# Altium schematic sheet PIC32CK.SchDoc(PIC32CK)
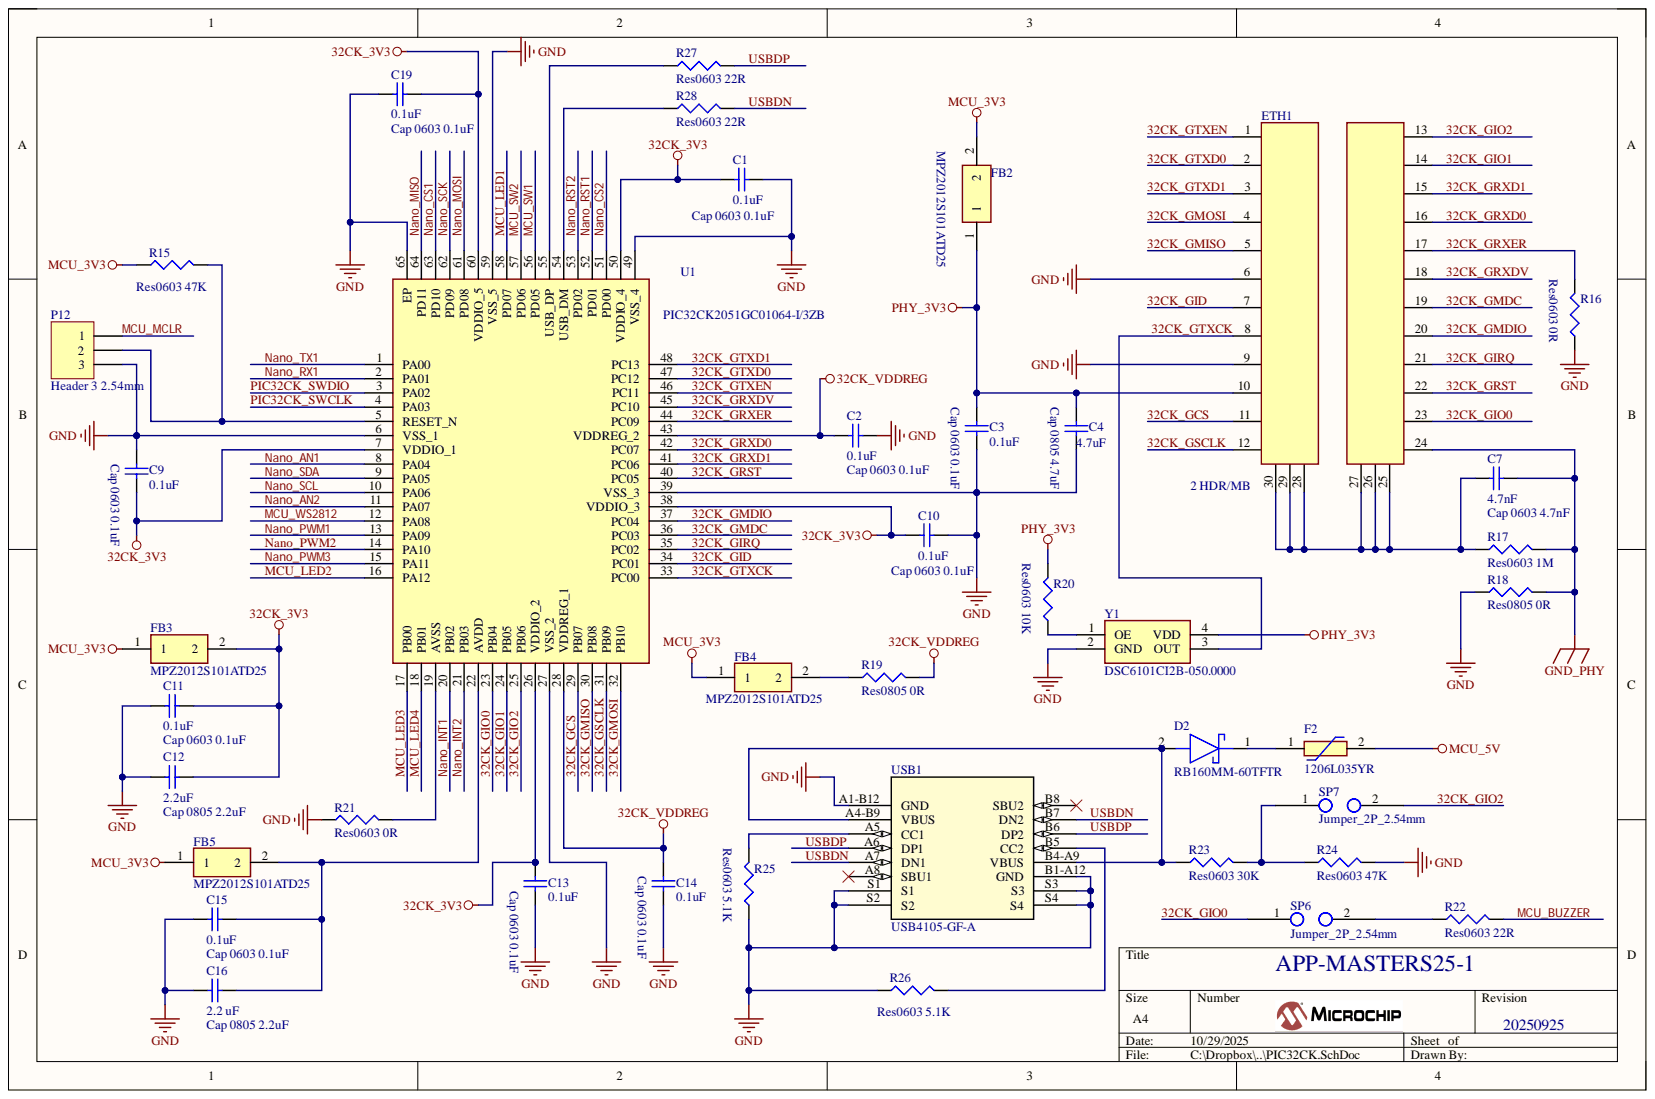
[diagram p0: PIC32CK.SchDoc]

## Parts
C1  Cap 0603 0.1uF  value 0.1uF  [0603C]
C2  Cap 0603 0.1uF  value 0.1uF  [0603C]
C3  Cap 0603 0.1uF  value 0.1uF  [0603C]
C4  Cap 0805 4.7uF  value 4.7uF  [C0805]
C7  Cap 0603 4.7nF  value 4.7nF  [0603C]
C9  Cap 0603 0.1uF  value 0.1uF  [0603C]
C10  Cap 0603 0.1uF  value 0.1uF  [0603C]
C11  Cap 0603 0.1uF  value 0.1uF  [0603C]
C12  Cap 0805 2.2uF  value 2.2uF  [C0805]
C13  Cap 0603 0.1uF  value 0.1uF  [0603C]
C14  Cap 0603 0.1uF  value 0.1uF  [0603C]
C15  Cap 0603 0.1uF  value 0.1uF  [0603C]
C16  Cap 0805 2.2uF  value 2.2 uF  [C0805]
C19  Cap 0603 0.1uF  value 0.1uF  [0603C]
D2  RB160MM-60TFTR  [PMDU]
ETH1  2 HDR/MB  [MOD_LAN8740_PHY_Daughter_Board]
F2  1206L035YR  [1206L05015YR]
FB2  MPZ2012S101ATD25  [BEADC2012X105N]
FB3  MPZ2012S101ATD25  [BEADC2012X105N]
FB4  MPZ2012S101ATD25  [BEADC2012X105N]
FB5  MPZ2012S101ATD25  [BEADC2012X105N]
P12  Header 3 2.54mm  [HDR1X3]
R15  Res0603 47K  value 47K  [0603R]
R16  Res0603 0R  value 0R  [0603R]
R17  Res0603 1M  value 1M  [0603R]
R18  Res0805 0R  value 0R  [RES0805]
R19  Res0805 0R  value 0R  [RES0805]
R20  Res0603 10K  value 10K  [0603R]
R21  Res0603 0R  value 0R  [0603R]
R22  Res0603 22R  value 22R  [0603R]
R23  Res0603 30K  value 30K  [0603R]
R24  Res0603 47K  value 47K  [0603R]
R25  Res0603 5.1K  value 5.1K  [0603R]
R26  Res0603 5.1K  value 5.1K  [0603R]
R27  Res0603 22R  value 22R  [0603R]
R28  Res0603 22R  value 22R  [0603R]
SP6  Jumper_2P_2.54mm  [HDR1X2]
SP7  Jumper_2P_2.54mm  [HDR1X2]
U1  PIC32CK2051GC01064-I/3ZB  [QFP50P1200X1200X120-65N]  (value not drawn)
USB1  USB4105-GF-A  [USB4105_GCT]
Y1  DSC6101CI2B-050.0000  [DSC6101CI1A0260000]

## Nets
32CK_3V3: C1.1 C9.1 C10.1 C11.2 C12.2 C13.1 C19.2 FB3.2 U1.7(VDDIO_1) U1.26(VDDIO_2) U1.38(VDDIO_3) U1.50(VDDIO_4) U1.60(VDDIO_5)
32CK_VDDREG: C2.1 C14.1 R19.2 U1.28(VDDREG_1) U1.43(VDDREG_2)
GND: C1.2 C2.2 C3.1 C4.1 C9.2 C10.2 C11.1 C12.1 C13.2 C14.2 C15.1 C16.1 C19.1 ETH1.6 ETH1.9 P12.3 R16.1 R18.1 R21.1 R24.2 R25.1 R26.1 U1.6(VSS_1) U1.27(VSS_2) U1.39(VSS_3) U1.49(VSS_4) U1.59(VSS_5) U1.65(EP) USB1.A1-B12(GND) USB1.B1-A12(GND) USB1.S1 USB1.S2 USB1.S3 USB1.S4 Y1.2(GND)
GND_PHY: C7.2 ETH1.24 R17.2 R18.2
MCU_3V3: FB2.2 FB3.1 FB4.1 FB5.1 R15.1
MCU_5V: F2.2
PHY_3V3: C3.2 C4.2 ETH1.10 FB2.1 R20.2 Y1.4(VDD)
32CK_GCS: ETH1.11 U1.29(PB07)
32CK_GID: ETH1.7 U1.34(PC01)
32CK_GIO0: ETH1.23 SP6.1 U1.23(PB04)
32CK_GIO1: ETH1.14 U1.24(PB05)
32CK_GIO2: ETH1.13 SP7.2 U1.25(PB06)
32CK_GIRQ: ETH1.21 U1.35(PC02)
32CK_GMDC: ETH1.19 U1.36(PC03)
32CK_GMDIO: ETH1.20 U1.37(PC04)
32CK_GMISO: ETH1.5 U1.30(PB08)
32CK_GMOSI: ETH1.4 U1.32(PB10)
32CK_GRST: ETH1.22 U1.40(PC05)
32CK_GRXD0: ETH1.16 U1.42(PC07)
32CK_GRXD1: ETH1.15 U1.41(PC06)
32CK_GRXDV: ETH1.18 U1.45(PC10)
32CK_GRXER: ETH1.17 R16.2 U1.44(PC09)
32CK_GSCLK: ETH1.12 U1.31(PB09)
32CK_GTXCK: ETH1.8 U1.33(PC00) Y1.3(OUT)
32CK_GTXD0: ETH1.2 U1.47(PC12)
32CK_GTXD1: ETH1.3 U1.48(PC13)
32CK_GTXEN: ETH1.1 U1.46(PC11)
MCU_BUZZER: R22.2
MCU_LED1: U1.58(PD07)
MCU_LED2: U1.16(PA12)
MCU_LED3: U1.17(PB00)
MCU_LED4: U1.18(PB01)
MCU_MCLR: P12.1
MCU_SW1: U1.56(PD05)
MCU_SW2: U1.57(PD06)
MCU_WS2812: U1.12(PA08)
Nano_AN1: U1.8(PA04)
Nano_AN2: U1.11(PA07)
Nano_CS1: U1.63(PD10)
Nano_CS2: U1.51(PD00)
Nano_INT1: U1.20(PB02)
Nano_INT2: U1.21(PB03)
Nano_MISO: U1.64(PD11)
Nano_MOSI: U1.61(PD08)
Nano_PWM1: U1.13(PA09)
Nano_PWM2: U1.14(PA10)
Nano_PWM3: U1.15(PA11)
Nano_RST1: U1.52(PD01)
Nano_RST2: U1.53(PD02)
Nano_RX1: U1.2(PA01)
Nano_SCK: U1.62(PD09)
Nano_SCL: U1.10(PA06)
Nano_SDA: U1.9(PA05)
Nano_TX1: U1.1(PA00)
PIC32CK_SWCLK: U1.4(PA03)
PIC32CK_SWDIO: U1.3(PA02)
USBDN: R28.2 USB1.A7(DN1) USB1.B7(DN2)
USBDP: R27.2 USB1.A6(DP1) USB1.B6(DP2)
NetC7_1: C7.1 ETH1.25 ETH1.26 ETH1.27 ETH1.28 ETH1.29 ETH1.30 R17.1
NetC15_2: C15.2 C16.2 FB5.2 U1.22(AVDD)
NetD2_1: D2.1 F2.1
NetD2_2: D2.2 R23.1 USB1.A4-B9(VBUS) USB1.B4-A9(VBUS)
NetFB4_2: FB4.2 R19.1
NetP12_2: P12.2 R15.2 U1.5(RESET_N)
NetR20_1: R20.1 Y1.1(OE)
NetR21_2: R21.2 U1.19(AVSS)
NetR22_1: R22.1 SP6.2
NetR23_2: R23.2 R24.1 SP7.1
NetR25_2: R25.2 USB1.A5(CC1)
NetR26_2: R26.2 USB1.B5(CC2)
NetR27_1: R27.1 U1.55(USB_DP)
NetR28_1: R28.1 U1.54(USB_DM)

Not connected: USB1 A8(SBU1) B8(SBU2)

## Library data of the parts
C1-C3 C7 C9-C11 C13-C15 C19 = Cap 0603: Capacitor (Semiconductor SIM Model); Component Kind: Standard; LatestRevisionDate: 29-May-2009; LatestRevisionNote: IPC-7351 Footprint Added.; Library Name: MCHP_Parts_2016.SchLib; PackageDescription: Chip Capacitor; PackageReference: C0603; Published: 8-Jun-2000; Publisher: Altium Limited
C4 C12 C16 = Cap 0805: Capacitor (Semiconductor SIM Model); Component Kind: Standard; LatestRevisionDate: 29-May-2009; LatestRevisionNote: IPC-7351 Footprint Added.; Library Name: MCHP_Parts_2016.SchLib; PackageDescription: Chip Capacitor; PackageReference: C1206; Published: 8-Jun-2000; Publisher: Altium Limited
D2 = RB160MM-60TFTR: Schottky Diode; Manufacturer_Name: ROHM Semiconductor; Component Kind: Standard; Datasheet Link: https://datasheet.datasheetarchive.com/originals/distributors/DKDS42/DSANUWW0049880.pdf; Manufacturer_Part_Number: RB160MM-60TFTR; Mouser Part Number: 755-RB160MM-60TFTR; Mouser Price/Stock: https://www.mouser.co.uk/ProductDetail/ROHM-Semiconductor/RB160MM-60TFTR?qs=4v%252BiZTmLVHHPhQMSldcR9A%3D%3D
ETH1 = LAN8740 PHY Daughter Board: PCB Assy, PIC32 LAN8740 PHY Daughter Board; Connector Type: Header; Description_: MOD PHY DB Connector Footprint; Dev Tools Status: Design; MCHP-ID: MOD0007; Number of Positions: 12; Number of Positions Loaded: All; Owner: Mike Ragsdale; Pitch: 0.050" (1.27mm); Populated: DNP; Category: Module; Color: -; Component Kind: Standard; ComponentLink1Description: Samtec; ComponentLink1URL: http://suddendocs.samtec.com/prints/fts-1xx-xx-x-sx-mkt.pdf; Contact Finish: Gold; Contact Finish Thickness: Flash; Contact Type: Female Socket; Fastening Type: -; Features: -; Library Name: MCHP_Parts_2016.SchLib; Mounting Type: Through Hole; Number of Rows: 1; Packaging: Bulk; Quantity Override: 1; Revision: 1; RoHS: RoHS Compliant; Row Spacing: -; Status: MCL Design; Stock 1: 101; Supplier Unit Price 1: 0.00; Symbol: MOD PHY DB Connector Footprint; Termination: Solder; Type: Ethernet
F2 = 1206L035YR: Resettable Fuse; Manufacturer_Name: LITTELFUSE; Arrow Part Number: 1206L035YR; Arrow Price/Stock: https://www.arrow.com/en/products/1206l035yr/littelfuse?region=nac; Component Kind: Standard; Datasheet Link: https://m.littelfuse.com/~/media/electronics/datasheets/resettable_ptcs/littelfuse_ptc_1206l_datasheet.pdf.pdf; Height: 0.75mm; Manufacturer_Part_Number: 1206L035YR; Mouser Part Number: 576-1206L035YR; Mouser Price/Stock: https://www.mouser.co.uk/ProductDetail/Littelfuse/1206L035YR?qs=PWhpLWeW8wd%2F%252B9d2B1EXww%3D%3D
FB2-FB5 = MPZ2012S221AT000: Ferrite Bead; Manufacturer_Name: TDK; Arrow Part Number: MPZ2012S221AT000; Arrow Price/Stock: https://www.arrow.com/en/products/mpz2012s221at000/tdk?region=nac; Component Kind: Standard; Datasheet Link: https://product.tdk.com/system/files/dam/doc/product/emc/emc/beads/catalog/beads_commercial_power_mpz2012_en.pdf; Height: 1.05mm; Library Name: SamacSys.SchLib; Manufacturer_Part_Number: MPZ2012S221AT000; Mouser Part Number: 810-MPZ2012S221AT000; Mouser Price/Stock: https://www.mouser.co.uk/ProductDetail/TDK/MPZ2012S221AT000?qs=pLY5GE0xrmJYuLs3YjLRbg%3D%3D
P12 = Header 3: Header, 3-Pin; Component Kind: Standard; LatestRevisionDate: 17-Jul-2002; LatestRevisionNote: Re-released for DXP Platform.; Library Name: MCHP_Parts_2016.SchLib; Publisher: Altium Limited
R15-R17 R20-R28 = Res0603: Resistor; Component Kind: Standard; LatestRevisionDate: 29-May-2009; LatestRevisionNote: IPC-7351 Footprint Added.; Library Name: MCHP_Parts_2016.SchLib; PackageDescription: Chip Resistor; PackageReference: J1-0603; Published: 8-Jun-2000; Publisher: Altium Limited
R18 R19 = Res0805: Resistor; Component Kind: Standard; LatestRevisionDate: 29-May-2009; LatestRevisionNote: IPC-7351 Footprint Added.; Library Name: MCHP_Parts_2016.SchLib; PackageDescription: Chip Resistor; PackageReference: J1-0603; Published: 8-Jun-2000; Publisher: Altium Limited
U1 = PIC32CK2051GC01064-I_3ZB: Integrated Circuit; Manufacturer_Name: Microchip; Component Kind: Standard; Datasheet Link: https://ww1.microchip.com/downloads/aemDocuments/documents/MCU32/ProductDocuments/DataSheets/PIC32CK-SG01-SG00-GC01-GC00-Family-Data-Sheet-DS60001795.pdf; Height: 1.2mm; Manufacturer_Part_Number: PIC32CK2051GC01064-I/3ZB; Mouser Part Number: 579-K2051GC01064I3ZB; Mouser Price/Stock: https://www.mouser.co.uk/ProductDetail/Microchip-Technology/PIC32CK2051GC01064-I-3ZB?qs=jRuttqqUwMRbh%2FQ9i%2FlgOw%3D%3D
USB1 = USB4105-GF-A: USB Type-C; Mfr_Name: Global Connector Technology; Component Kind: Standard; Library Name: MCHP_Parts_2016.SchLib; RefDes: RefDes; Type: Type
Y1 = DSC6101CI1A-026.0000: Crystal or Oscillator; Manufacturer_Name: Microchip; Component Kind: Standard; Datasheet Link: http://www.microchip.com/mymicrochip/filehandler.aspx?ddocname=en587492; Height: 0.9mm; Manufacturer_Part_Number: DSC6101CI1A-026.0000; Mouser Part Number: 579-101CI1A0260000; Mouser Price/Stock: https://www.mouser.com/Search/Refine.aspx?Keyword=579-101CI1A0260000
SP6 SP7 = Jumper_2P_2.54mm: Component Kind: Standard; Library Name: MCHP_Parts_2016.SchLib
